# Altium schematic sheet MCP2562FD.SchDoc(MCP2562FD)
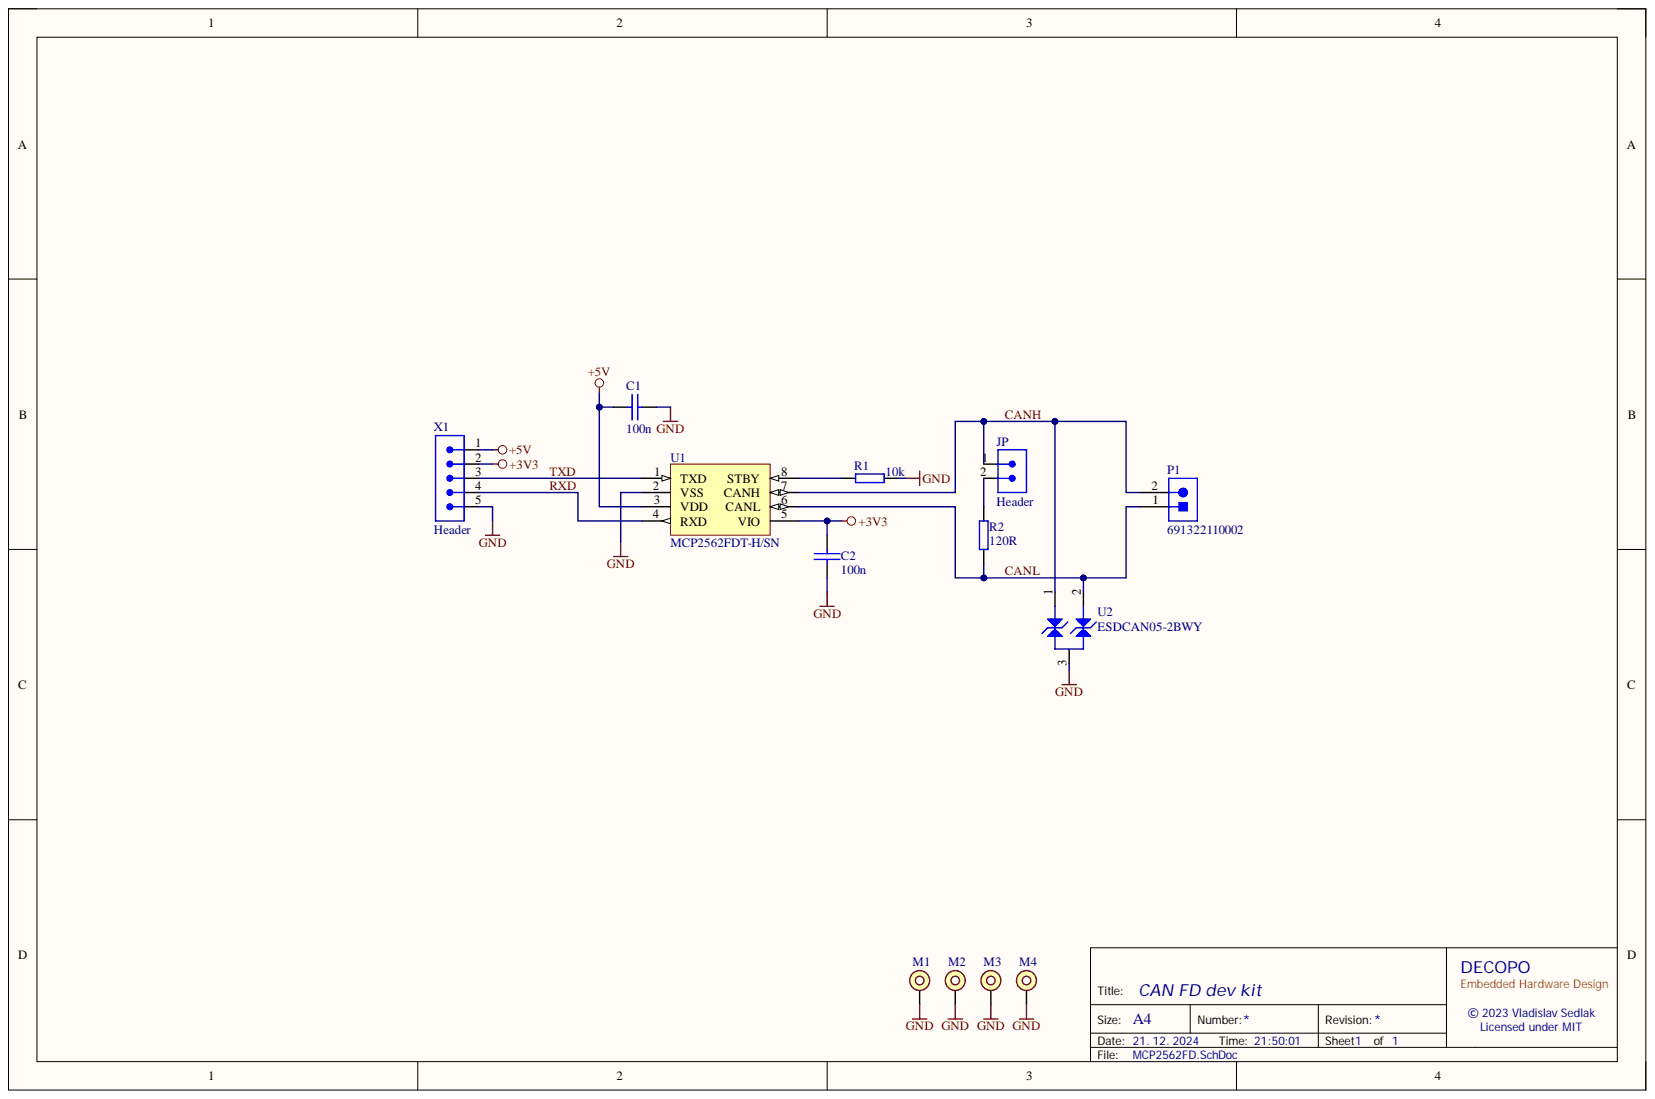
[diagram p0: MCP2562FD.SchDoc]

## Parts
C1  100n  [FP-C1608-080-0_1-MFG]
C2  100n  [FP-C1608-080-0_1-MFG]
JP  Header  [pin hdr 2.54 1x2]
M1  MTG240_500  [MTG240_500]  (value not drawn)  (no BOM)
M2  MTG240_500  [MTG240_500]  (value not drawn)  (no BOM)
M3  MTG240_500  [MTG240_500]  (value not drawn)  (no BOM)
M4  MTG240_500  [MTG240_500]  (value not drawn)  (no BOM)
P1  691322110002  [691322110002]
R1  10k  [FP-CRCW0603-e3-MFG]
R2  120R  [FP-CRCW0603-e3-MFG]
U1  MCP2562FD  value MCP2562FDT-H/SN  Manufacturer_Part_Number: MCP2562FDT-H/SN  [ICC_SO8_5X4_P127]
U2  value ESDCAN05-2BWY  Manufacturer_Part_Number: ESDCAN05-2BWY  [DIO_SOT323]  (hidden comment: ESD-TRANSIL-DUAL-BIDIR)
X1  Header  [pin hdr 2.54 1x5]

## Nets
+3V3: C2.2 U1.5(VIO) X1.2
+5V: C1.1 U1.3(VDD) X1.1
GND: C1.2 C2.1 M1.M1 M2.M1 M3.M1 M4.M1 R1.2 U1.2(VSS) U2.3 X1.5
CANH: JP.1 P1.2 U1.7(CANH) U2.1
CANL: P1.1 R2.1 U1.6(CANL) U2.2
RXD: U1.4(RXD) X1.4
TXD: U1.1(TXD) X1.3
NetJP_2: JP.2 R2.2
NetR1_1: R1.1 U1.8(STBY)

## Library data of the parts
C1 C2 = 39: Capacitor 100n 0603 X7R 50V 10%; Database Table Name: Capacitors$; Capacitance: 100n; Component Kind: Standard; Dielectric: X7R; Max Operating Temperature: 125°C; Min Operating Temperature: -55°C; Package: 0603; Tolerance: 10%; Unique: 39; Voltage Rating: 50V; Library Name: pasive.DbLib
JP = pin hdr 2.54 1x2: Pin header 2.54mm 1 row, 2 pins; Component Kind: Standard; Library Name: connector.SchLib
M1-M4 = MTG240_500: Mounting hole; DescriptionSK: Upevňovacia diera; Library Name: mechanical.SchLib; PackageType: Mech; PartType: MTG240_500
P1 = 691322110002: WR-TBL Serie 3221 Horizontal PCB Header, Pluggable, THT, pitch 3.5mm, 2p; Manufacturer: Wurth Elektronik; Modularity: No; Pin Number: 2; Rated Current [UL]: 10A; Working Voltage [UL]: 300VAC; Application: Pluggable; Component Kind: Standard; ComponentLink1Description: Manufacturer; ComponentLink1URL: http://www.we-online.de; ComponentLink2Description: Datasheet; ComponentLink2URL: https://katalog.we-online.de/em/datasheet/691322110002.pdf; Library Name: connector.SchLib; Manufacturer Part Number: 691322110002; Mounting Technology: THT; Operating Temperature: -40 to +105degC; Packaging: Box; PCB/Cable/Panel: PCB; Pitch: 3.5mm; Published Date: Feburary 2021; Type: Horizontal
R1 = 136: Resistor 10k 0603 1% 75V 100mW; Database Table Name: Resistors$; Temperature Coefficient: 100ppm/°C; Component Kind: Standard; Max Operating Temperature: 155°C; Max Power Dissipation: 100mW; Min Operating Temperature: -55°C; Package: 0603; Resistance: 10k; Tolerance: 1%; Unique: 136; Voltage Rating: 75V; Library Name: pasive.DbLib
R2 = 113: Resistor 120R 0603 1% 75V 100mW; Database Table Name: Resistors$; Temperature Coefficient: 100ppm/°C; Component Kind: Standard; Max Operating Temperature: 155°C; Max Power Dissipation: 100mW; Min Operating Temperature: -55°C; Package: 0603; Resistance: 120R; Tolerance: 1%; Unique: 113; Voltage Rating: 75V; Library Name: pasive.DbLib
U1 = if MCP2562FD: High-Speed CAN Flexible Data Rate Transceiver; Internal_Part_Number: MCD-00129; Manufacturer: MICROCHIP; Part_Type: IC; Provided_By: SUBCO; Component Kind: Standard; ComponentLink1Description: Datasheet; ComponentLink1URL: http://tunmcdsvn.tun.st.com/Component_Datasheet_Library/Trunk/IC/Microchip_CAN Transceiver_MCP2562FD; Library Name: ic.SchLib; Status: Released
U2 = ESD-TRANSIL-DUAL-BIDIR: Automotive dual-line Transil, transient voltage suppressor (TVS) for CAN bus 36V. Compliant with interfaces in automotive: CAN-FD, LIN, FlexRay, MOST, SENT, USB; BMS_Part_Number: 9179021; Internal_Part_Number: MCD-01358; Manufacturer: STMICROELECTRONICS; Part_Type: Diode; ECCN_EU: NEC; ECCN_US: EAR99; Provided_By: SUBCO with ST control; Component Kind: Standard; ComponentLink1Description: Datasheet; ComponentLink1URL: http://tunmcdsvn.tun.st.com/Component_Datasheet_Library/Trunk/Diodes/STM_ESD_ESDCAN02-2BWY; Library Name: ic.SchLib; Package: SOT323-3L; Status: Released
X1 = pin hdr 2.54 1x5: Pin header 2.54mm 1 row, 5 pins; Component Kind: Standard; Library Name: connector.SchLib
